# Altium schematic sheet 02 - Breakout.SchDoc("02 - Breakout")
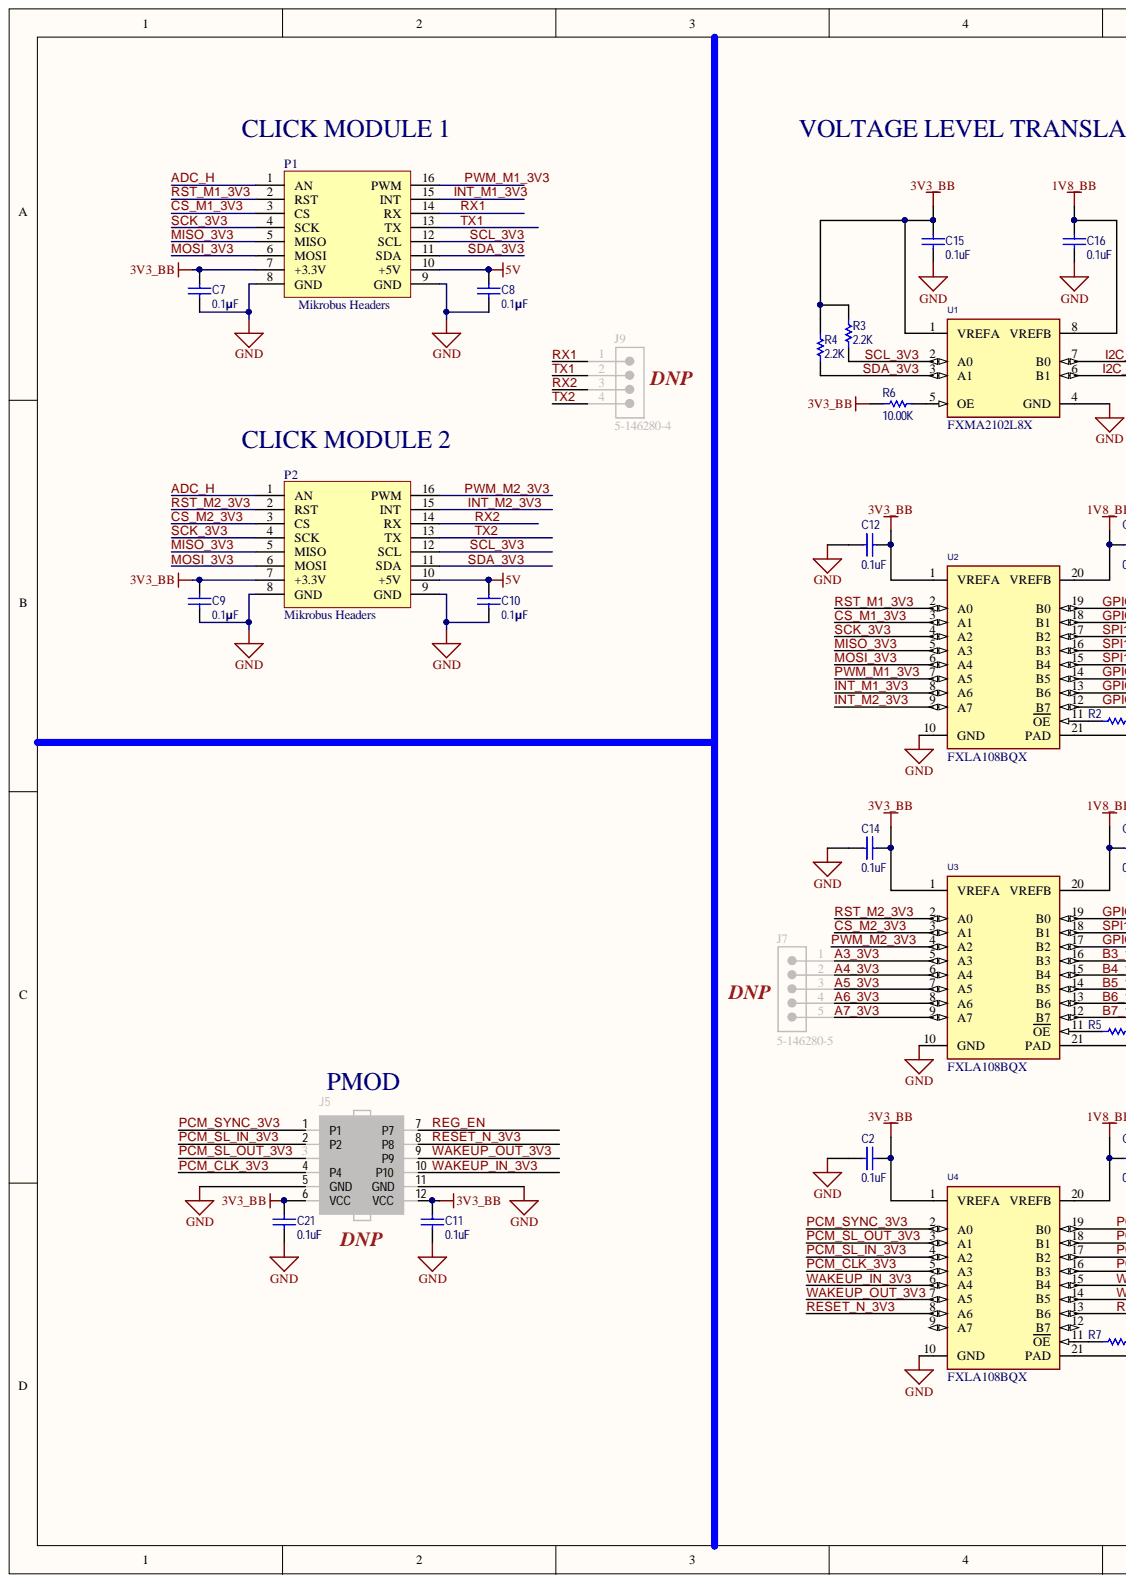
[diagram p0: 02 - Breakout.SchDoc, left]
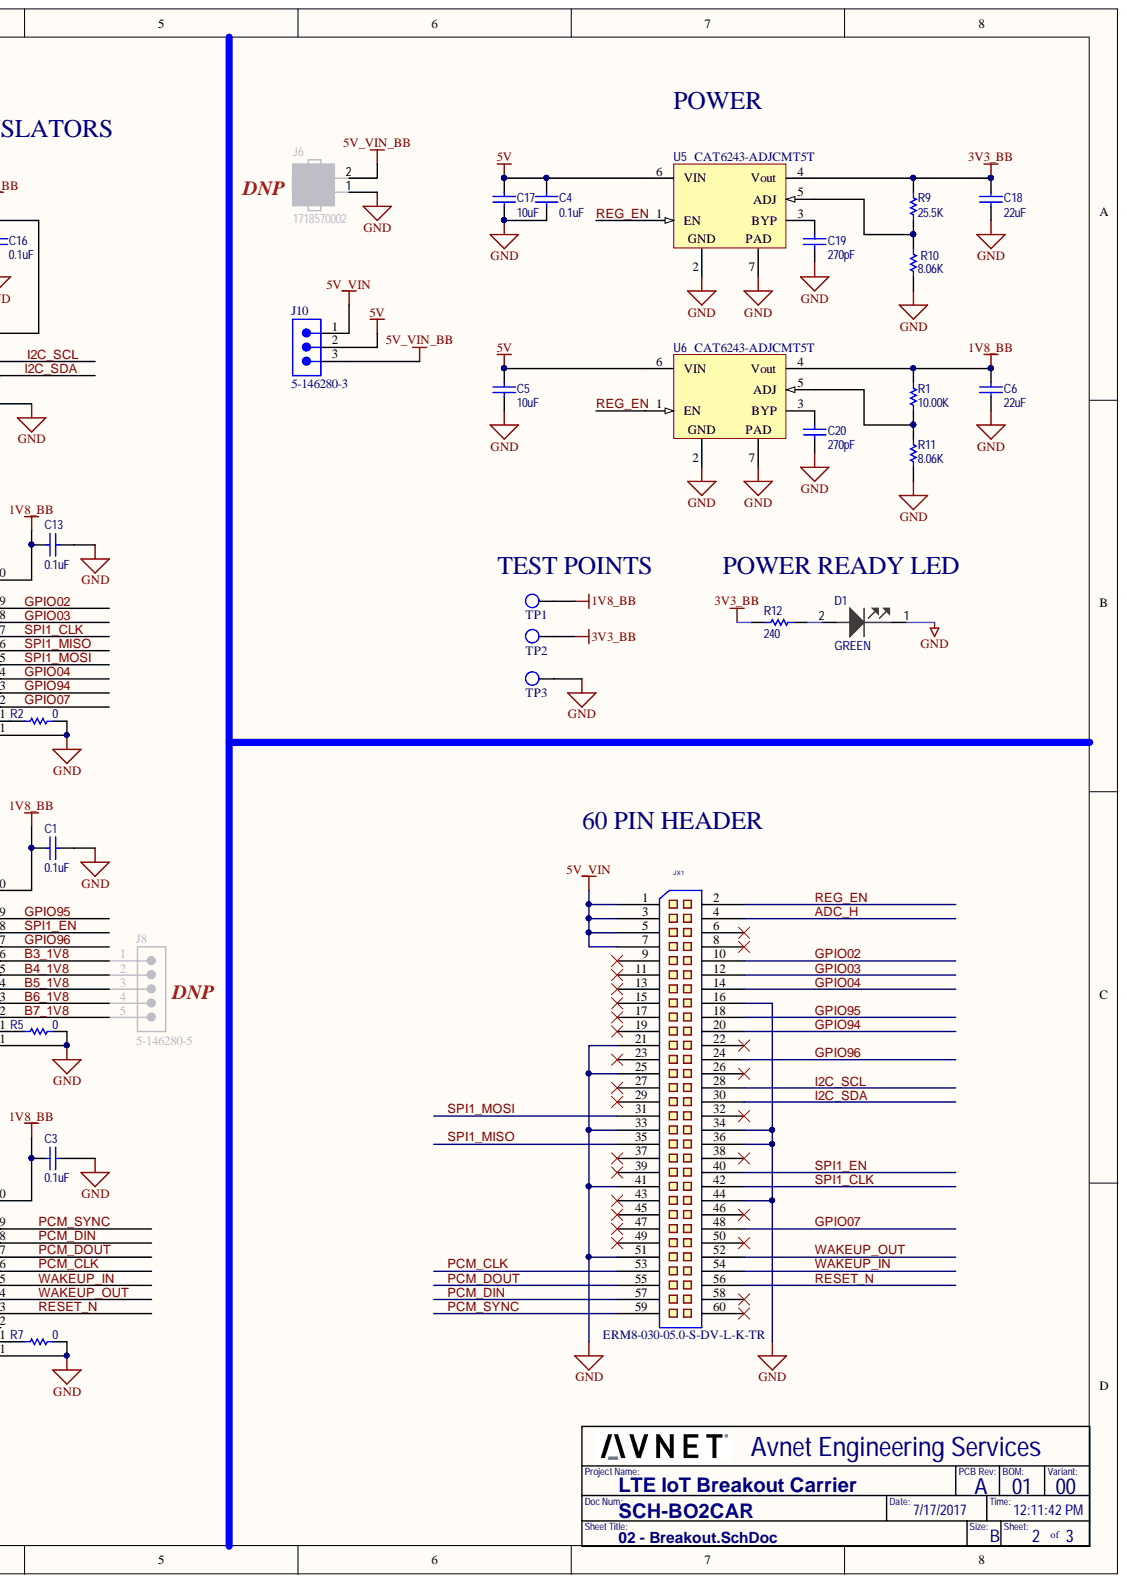
[diagram p1: 02 - Breakout.SchDoc, right]

## Parts
C1  0.1uF  [CAPC0402(1005)70_N]
C2  0.1uF  [CAPC0402(1005)70_N]
C3  0.1uF  [CAPC0402(1005)70_N]
C4  0.1uF  [CAPC0603(1608)100_N]
C5  10uF  [CAPC0402(1005)70_N]
C6  22uF  [CAPC0805(2012)155_N]
C7  Capacitance: 0.1µF  [CAPC0402(1005)70_N]  (hidden comment: 0.1uF)
C8  Capacitance: 0.1µF  [CAPC0402(1005)70_N]  (hidden comment: 0.1uF)
C9  Capacitance: 0.1µF  [CAPC0402(1005)70_N]  (hidden comment: 0.1uF)
C10  Capacitance: 0.1µF  [CAPC0402(1005)70_N]  (hidden comment: 0.1uF)
C11  0.1uF  [CAPC0402(1005)70_N]
C12  0.1uF  [CAPC0402(1005)70_N]
C13  0.1uF  [CAPC0402(1005)70_N]
C14  0.1uF  [CAPC0402(1005)70_N]
C15  0.1uF  [CAPC0402(1005)70_N]
C16  0.1uF  [CAPC0402(1005)70_N]
C17  10uF  [CAPC0402(1005)70_N]
C18  22uF  [CAPC0805(2012)155_N]
C19  270pF  [CAPC0402(1005)70_N]
C20  270pF  [CAPC0402(1005)70_N]
C21  0.1uF  [CAPC0402(1005)70_N]
D1  Color: GREEN  Component Type: LED  [D0603 (1608 Metric), GREEN]  (hidden comment: LED SMARTLED 570NM GREEN 0603)
J5  INDUSTRIAL  [REC-PTH-VERT-12D-0.100 (2.54mm)]  (value not drawn)
J6  1718570002  [1718570002]
J7  5-146280-5  [HDR-U-TH-VERT-5S-0.100 (2.54mm)]
J8  5-146280-5  [HDR-U-TH-VERT-5S-0.100 (2.54mm)]
J9  5-146280-4  [HDR-U-TH-VERT-4S-0.100 (2.54mm)]
J10  5-146280-3  [HDR-U-TH-VERT-3S-0.100 (2.54mm)]
JX1  ERM8-030-05.0-S-DV-L-K-TR  [ERM8-030-XX.X-X-DV]
P1  Mikrobus Headers  Material: Mikrobus  [MIKROBUS HOST CONN.]  (no BOM)
P2  Mikrobus Headers  Material: Mikrobus  [MIKROBUS HOST CONN.]  (no BOM)
R1  10.00K  [RESC0402(1005)_N]
R2  0  [RESC0402(1005)_N]
R3  2.2K  [RESC0402(1005)_N]
R4  2.2K  [RESC0402(1005)_N]
R5  0  [RESC0402(1005)_N]
R6  10.00K  [RESC0402(1005)_N]
R7  0  [RESC0402(1005)_N]
R9  25.5K  [RESC0402(1005)_N]
R10  8.06K  [RESC0402(1005)_N]
R11  8.06K  [RESC0402(1005)_N]
R12  240  [RESC0402(1005)_N]
TP1  Test Point-0.045"  [TP1]  (value not drawn)  (no BOM)
TP2  Test Point-0.045"  [TP1]  (value not drawn)  (no BOM)
TP3  Test Point-0.045"  [TP1]  (value not drawn)  (no BOM)
U1  FXMA2102L8X  [8-UFQFN_FXMA2102]
U2  FXLA108BQX  [20-WFQFN_EP_FXLA108]
U3  FXLA108BQX  [20-WFQFN_EP_FXLA108]
U4  FXLA108BQX  [20-WFQFN_EP_FXLA108]
U5  CAT6243-ADJCMT5T  [6-WDFN Exposed Pad]
U6  CAT6243-ADJCMT5T  [6-WDFN Exposed Pad]

## Nets
1V8_BB: C1.1 C3.1 C6.2 C13.1 C16.2 R1.2 TP1.1 U1.8(VREFB) U2.20(VREFB) U3.20(VREFB) U4.20(VREFB) U6.4(Vout)
3V3_BB: C2.2 C7.2 C9.2 C11.2 C12.1 C14.2 C15.2 C18.2 C21.2 J5.6(VCC) J5.12(VCC) P1.7(+3.3V) P2.7(+3.3V) R3.2 R4.2 R6.1 R9.2 R12.1 TP2.1 U1.1(VREFA) U2.1(VREFA) U3.1(VREFA) U4.1(VREFA) U5.4(Vout)
5V: C4.1 C5.2 C8.2 C10.2 C17.2 J10.2 P1.10(+5V) P2.10(+5V) U5.6(VIN) U6.6(VIN)
5V_VIN: J10.1 JX1.1 JX1.3 JX1.5 JX1.7
5V_VIN_BB: J6.2 J10.3
GND: C1.2 C2.1 C3.2 C4.2 C5.1 C6.1 C7.1 C8.1 C9.1 C10.1 C11.1 C12.2 C13.2 C14.1 C15.1 C16.1 C17.1 C18.1 C19.1 C20.1 C21.1 D1.1 J5.5(GND) J5.11(GND) J6.1 JX1.16 JX1.21 JX1.25 JX1.33 JX1.34 JX1.36 JX1.41 JX1.44 JX1.51 P1.8(GND) P1.9(GND) P2.8(GND) P2.9(GND) R2.2 R5.2 R7.2 R10.1 R11.1 TP3.1 U1.4(GND) U2.10(GND) U2.21(PAD) U3.10(GND) U3.21(PAD) U4.10(GND) U4.21(PAD) U5.2 U5.7 U6.2 U6.7
A3_3V3: J7.1 U3.5(A3)
A4_3V3: J7.2 U3.6(A4)
A5_3V3: J7.3 U3.7(A5)
A6_3V3: J7.4 U3.8(A6)
A7_3V3: J7.5 U3.9(A7)
ADC_H: JX1.4 P1.1(AN) P2.1(AN)
B3_1V8: J8.1 U3.16(B3)
B4_1V8: J8.2 U3.15(B4)
B5_1V8: J8.3 U3.14(B5)
B6_1V8: J8.4 U3.13(B6)
B7_1V8: J8.5 U3.12(B7)
CS_M1_3V3: P1.3(CS) U2.3(A1)
CS_M2_3V3: P2.3(CS) U3.3(A1)
GPIO02: JX1.10 U2.19(B0)
GPIO03: JX1.12 U2.18(B1)
GPIO04: JX1.14 U2.14(B5)
GPIO07: JX1.48 U2.12(B7)
GPIO94: JX1.20 U2.13(B6)
GPIO95: JX1.18 U3.19(B0)
GPIO96: JX1.24 U3.17(B2)
I2C_SCL: JX1.28 U1.7(B0)
I2C_SDA: JX1.30 U1.6(B1)
INT_M1_3V3: P1.15(INT) U2.8(A6)
INT_M2_3V3: P2.15(INT) U2.9(A7)
MISO_3V3: P1.5(MISO) P2.5(MISO) U2.5(A3)
MOSI_3V3: P1.6(MOSI) P2.6(MOSI) U2.6(A4)
PCM_CLK: JX1.53 U4.16(B3)
PCM_CLK_3V3: J5.4(P4) U4.5(A3)
PCM_DIN: JX1.57 U4.18(B1)
PCM_DOUT: JX1.55 U4.17(B2)
PCM_SL_IN_3V3: J5.2(P2) U4.4(A2)
PCM_SL_OUT_3V3: J5.3(P3) U4.3(A1)
PCM_SYNC: JX1.59 U4.19(B0)
PCM_SYNC_3V3: J5.1(P1) U4.2(A0)
PWM_M1_3V3: P1.16(PWM) U2.7(A5)
PWM_M2_3V3: P2.16(PWM) U3.4(A2)
REG_EN: J5.7(P7) JX1.2 U5.1(EN) U6.1(EN)
RESET_N: JX1.56 U4.13(B6)
RESET_N_3V3: J5.8(P8) U4.8(A6)
RST_M1_3V3: P1.2(RST) U2.2(A0)
RST_M2_3V3: P2.2(RST) U3.2(A0)
RX1: J9.1 P1.14(RX)
RX2: J9.3 P2.14(RX)
SCK_3V3: P1.4(SCK) P2.4(SCK) U2.4(A2)
SCL_3V3: P1.12(SCL) P2.12(SCL) R3.1 U1.2(A0)
SDA_3V3: P1.11(SDA) P2.11(SDA) R4.1 U1.3(A1)
SPI1_CLK: JX1.42 U2.17(B2)
SPI1_EN: JX1.40 U3.18(B1)
SPI1_MISO: JX1.35 U2.16(B3)
SPI1_MOSI: JX1.31 U2.15(B4)
TX1: J9.2 P1.13(TX)
TX2: J9.4 P2.13(TX)
WAKEUP_IN: JX1.54 U4.15(B4)
WAKEUP_IN_3V3: J5.10(P10) U4.6(A4)
WAKEUP_OUT: JX1.52 U4.14(B5)
WAKEUP_OUT_3V3: J5.9(P9) U4.7(A5)
NetC19_2: C19.2 U5.3(BYP)
NetC20_2: C20.2 U6.3(BYP)
NetD1_2: D1.2 R12.2
NetR1_1: R1.1 R11.2 U6.5(ADJ)
NetR2_1: R2.1 U2.11(OE)
NetR5_1: R5.1 U3.11(OE)
NetR6_2: R6.2 U1.5(OE)
NetR7_1: R7.1 U4.11(OE)
NetR9_1: R9.1 R10.2 U5.5(ADJ)

Not connected: JX1 6 8 9 11 13 15 17 19 22 23 26 27 29 32 37 38 39 43 45 46 47 49 50 58 60; U4 9(A7) 12(B7)

## Library data of the parts
C1-C3 C11-C16 C21 = AVPC-0000222,A-2: CAP CER 0.1UF 16V 10% X5R 0402; Material: CERAMIC; MFG 1: AVX; MFGPN 1: 0402YD104KAT2A; Mount Type (SM/TH): SM; Numeric Value (R=Ω, C=uF, L=uH, FB=Ω ): 0.1; Polarized (Y/N): N; Preferrence Code: A; Temp-Range: -55 to 85C; AES PN: AVPC-0000222; Component Kind: Standard; Component Type: CAP; Dielectric Type: X5R; MFG 1 Datasheet link: http://datasheets.avx.com/cx5r.pdf; Package: 0402 (1005 Metric); Rated Voltage: 16V; RoHS: Y; Tolerance: 10%; Value: 0.1uF; Library Name: AES Altium Vault
C4 = AVPC-0000061,A-1: CAP CER 0.1UF 50V 10% X7R 0603; Material: CERAMIC; MFG 1: AVX; MFGPN 1: 06035C104KAT2A; Mount Type (SM/TH): SM; Numeric Value (R=Ω, C=uF, L=uH, FB=Ω ): 0.1; Polarized (Y/N): N; Preferrence Code: A; Temp-Range: -55 to 125C; AES PN: AVPC-0000061; Component Kind: Standard; Component Type: CAP; Dielectric Type: X7R; MFG 1 Datasheet link: http://www.avx.com/docs/Catalogs/cx7r.pdf; Package: 0603 (1608 Metric); Rated Voltage: 50V; RoHS: Y; Tolerance: 10%; Value: 0.1uF; Library Name: AES Altium Vault
C5 C17 = AVPC-0000035,A-1: CAP CER 10UF 10V 20% X5R 0402; Material: CERAMIC; MFG 1: SAMSUNG; MFGPN 1: CL05A106MP5NUNC; Mount Type (SM/TH): SM; Numeric Value (R=Ω, C=uF, L=uH, FB=Ω ): 10; Polarized (Y/N): N; Preferrence Code: A; Temp-Range: -55 to 85C; AES PN: AVPC-0000035; Component Kind: Standard; Component Type: CAP; Dielectric Type: X5R; MFG 1 Datasheet link: http://www.samsungsem.com/global/support/library/product-catalog/__icsFiles/afieldfile/2014/11/13/MLCC.pdf; Package: 0402 (1005 Metric); Rated Voltage: 10V; RoHS: Y; Tolerance: 20%; Value: 10uF; Library Name: AES Altium Vault
C6 C18 = AVPC-0000307,A-1: CAP CER 22UF 10V 20% X5R 0805; Material: CERAMIC; MFG 1: AVX; MFGPN 1: 0805ZD226MAT2A; Mount Type (SM/TH): SM; Numeric Value (R=Ω, C=uF, L=uH, FB=Ω ): 22; Polarized (Y/N): N; Preferrence Code: A; Temp-Range: -55 to 85C; AES PN: AVPC-0000307; Component Kind: Standard; Component Type: CAP; Dielectric Type: X5R; MFG 1 Datasheet link: http://datasheets.avx.com/cx5r.pdf; Package: 0805 (2012 Metric); Rated Voltage: 10V; RoHS: Y; Tolerance: 20%; Value: 22uF; Library Name: AES Altium Vault
C7-C10 = AVPC-0000034,A-1: CAP CER 0.1UF 25V 10% X7R 0402; Manufacturer: AVX Corporation; Manufacturer Part Number: 06035C104KAT2A; Material: CERAMIC; MFG 1: AVX; MFGPN 1: 04023C104KAT2A; Mount Type (SM/TH): SM; Numeric Value (R=Ω, C=uF, L=uH, FB=Ω ): 0.1; Polarized (Y/N): N; Preferrence Code: A; Temp-Range: -55 to 125C; Voltage - Rated: 50V; AES PN: AVPC-0000034; Applications: General Purpose; Category: Capacitors; Component Kind: Standard; ComponentLink1Description: http://www.avx.com/docs/Catalogs/cx7r.pdf; ComponentLink1URL: http://www.avx.com/docs/Catalogs/cx7r.pdf; Component Type: CAP; Dielectric Type: X7R; Features: -; Height - Seated (Max): -; Lead Spacing: -; Lead Style: -; MFG 1 Datasheet link: http://www.avx.com/docs/Catalogs/cx7r.pdf; Mounting Type: Surface Mount, MLCC; Operating Temperature: -55°C ~ 125°C; Package: 0402 (1005 Metric); Package / Case: 0603 (1608 Metric); Packaging: Cut Tape (CT); Rated Voltage: 25V; Ratings: -; RoHS: Y; Size / Dimension: 0.063" L x 0.032" W (1.60mm x 0.81mm); Temperature Coefficient: X7R; Thickness (Max): 0.035" (0.90mm); Tolerance: 10%; Value: 0.1uF; Library Name: AES Altium Vault
C19 C20 = AVPC-0000194,A-0: CAP CER 270PF 50V 10% X7R 0402; Material: CERAMIC; MFG 1: AVX; MFGPN 1: 04025C271KAT2A; Mount Type (SM/TH): SM; Numeric Value (R=Ω, C=uF, L=uH, FB=Ω ): 0.00027; Polarized (Y/N): N; Preferrence Code: A; Temp-Range: -55 to 125C; AES PN: AVPC-0000194; Component Kind: Standard; Component Type: CAP; Dielectric Type: X7R; MFG 1 Datasheet link: http://datasheets.avx.com/X7RDielectric.pdf; Package: 0402 (1005 Metric); Rated Voltage: 50V; RoHS: Y; Tolerance: 10%; Value: 270pF; Library Name: AES Altium Vault
D1 = AVDL-0000010,A-0: Forward Voltage: 1.7V; Lense Type: Diffused; MFG 1: OSRAM; MFGPN 1: LG L29K-F2J1-24-Z; Millicandela Rating: 3.5mcd; Mount Type (SM/TH): SM; Preferrence Code: A; Temp-Range: -40 to 85C; Wave-PK: 572nm; AES PN: AVDL-0000010; Component Kind: Standard; Description: LED SMARTLED 570NM GREEN 0603; MFG 1 Datasheet link: http://www.osram-os.com/Graphics/XPic2/00077107_0.pdf; Package: D0603 (1608 Metric); RoHS: Y; Wavelength: 570nm; Library Name: AES Altium Vault
J5 = AVEC-0000004,A-0: CONN RCPT 12POS .100" DUAL VERT; Header (M/F): F; MFG 1: SAMTEC; MFGPN 1: BCS-106-L-D-TE; Mount Type (SM/TH): TH; Pin Pitch: 0.100" (2.54mm); Preferrence Code: A; Shrouded (Y/N): N; Temp-Range: -55 to 125C; AES PN: AVEC-0000004; Color: Black; Component Kind: Standard; Component Type: CONNECTOR; Contact Mating Length: -; Contact Type: Gold, 10µin (0.25µm); Fastening Type: -; Features: -; Material: RECEPTACLE; MFG 1 Datasheet link: http://www.samtec.com/documents/webfiles/cpdf/BCS-1XX-XXX-X-XX-XXX-MKT.pdf; Number of Pins: 12; Number of Positions: -; Number of Rows: 2; Orientation: Vertical; Package: REC-PTH-VERT-12D-0.100 (2.54mm); Rated Current: -; Rated Voltage: -; RoHS: Y; Row Pitch: 0.100" (2.54mm); Library Name: AES Altium Vault
J6 = AVEC-0000143,A-0: KK RPC 100 HDR FRLK RTAN 02 CKT; Header (M/F): M; MFG 1: MOLEX; Mount Type (SM/TH): TH; Number of Positions: 2; Pin Pitch: 0.100(2.54mm); Preferrence Code: A; Rated Current: 4.0A (Per Pin); Rated Voltage: DWV=750V; Shrouded (Y/N): N; Temp-Range: -40 to 85C; AES PN: AVEC-0000143; Color: BLACK; Component Kind: Standard; Component Type: CONNECTOR; Contact Mating Length: 0.265" (6.73mm); Contact Type: 60µin (1.52µm); Material: HEADER; MFG 1 Datasheet link: http://www.molex.com/pdm_docs/sd/1718570002_sd.pdf; MFGPN 1: 1718570002; Number of Pins: 2; Number of Rows: 1; Orientation: RIGHT ANGLE; Package: 1718570002; RoHS: Y; Row Pitch: 0.100(2.54mm); Library Name: AES Altium Vault
J7 J8 = AVEC-0000016,A-2: CONN HEADR BRKWAY .100 5POS STR, SINGLE ROW; Header (M/F): M; MFG 1: TE; Mount Type (SM/TH): TH; Number of Positions: 5; Pin Pitch: 0.100(2.54mm); Preferrence Code: A; Rated Current: 3.0A (Per Pin); Rated Voltage: DWV=750V; Schematic Symbol: 5-Pin, Male, Single Row; Shrouded (Y/N): N; Temp-Range: -65 to 105C; AES PN: AVEC-0000016; Color: BLACK; Component Kind: Standard; Component Type: CONNECTOR; Contact Mating Length: 0.230" (5.84mm); Contact Type: Gold, 15µin (0.38µm); Fastening Type: -; Features: -; Material: HEADER; MFG 1 Datasheet link: http://www.te.com/commerce/DocumentDelivery/DDEController?Action=srchrtrv&DocNm=146280&DocType=Customer+Drawing&DocLang=English; MFGPN 1: 5-146280-5; Number of Pins: 5; Number of Rows: 1; Orientation: Vertical; Package: HDR-U-TH-VERT-5S-0.100 (2.54mm); RoHS: Y; Row Pitch: -; Library Name: AES Altium Vault
J9 = AVEC-0000015,A-2: CONN HEADR BRKWAY .100 4POS STR, SINGLE ROW; Header (M/F): M; MFG 1: TE; Mount Type (SM/TH): TH; Number of Positions: 4; Pin Pitch: 0.100(2.54mm); Preferrence Code: A; Rated Current: 3.0A (Per Pin); Rated Voltage: DWV=750V; Schematic Symbol: 4-Pin, Male, Single Row; Shrouded (Y/N): N; Temp-Range: -65 to 105C; AES PN: AVEC-0000015; Color: BLACK; Component Kind: Standard; Component Type: CONNECTOR; Contact Mating Length: 0.230" (5.84mm); Contact Type: Gold, 15µin (0.38µm); Fastening Type: -; Features: -; Material: HEADER; MFG 1 Datasheet link: http://www.te.com/commerce/DocumentDelivery/DDEController?Action=srchrtrv&DocNm=146280&DocType=Customer+Drawing&DocLang=English; MFGPN 1: 5-146280-4; Number of Pins: 4; Number of Rows: 1; Orientation: Vertical; Package: HDR-U-TH-VERT-4S-0.100 (2.54mm); RoHS: Y; Row Pitch: -; Library Name: AES Altium Vault
J10 = AVEC-0000014,A-2: CONN HEADR BRKWAY .100 3POS STR, SINGLE ROW; Header (M/F): M; MFG 1: TE; Mount Type (SM/TH): TH; Number of Positions: 3; Pin Pitch: 0.100(2.54mm); Preferrence Code: A; Rated Current: 3.0A (Per Pin); Rated Voltage: DWV=750V; Schematic Symbol: 3-Pin, Male, Single Row; Shrouded (Y/N): N; Temp-Range: -65 to 105C; AES PN: AVEC-0000014; Color: BLACK; Component Kind: Standard; Component Type: CONNECTOR; Contact Mating Length: 0.230" (5.84mm); Contact Type: Gold, 15µin (0.38µm); Fastening Type: -; Features: -; Material: HEADER; MFG 1 Datasheet link: http://www.te.com/commerce/DocumentDelivery/DDEController?Action=srchrtrv&DocNm=146280&DocType=Customer+Drawing&DocLang=English; MFGPN 1: 5-146280-3; Number of Pins: 3; Number of Rows: 1; Orientation: Vertical; Package: HDR-U-TH-VERT-3S-0.100 (2.54mm); RoHS: Y; Row Pitch: -; Library Name: AES Altium Vault
JX1 = AVEC-0000140,A-0: .8MM EDGE RATE TERMINAL ASSEMBLY; Header (M/F): M; MFG 1: SAMTEC; Mount Type (SM/TH): SM; Number of Positions: 30; Pin Pitch: 0.030" (0.762mm); Preferrence Code: A; Row Pitch: 0.031" (0.80mm); Shrouded (Y/N): Y; Temp-Range: -55 to 125C; AES PN: AVEC-0000140; Color: Black; Component Kind: Standard; Component Type: CONNECTOR; Contact Mating Length: 0.309" (7.84mm); Contact Type: Gold, 50uin (1.27um); Features: Board Guide; Material: HEADER; MFG 1 Datasheet link: http://suddendocs.samtec.com/catalog_english/erm5.pdf; MFGPN 1: ERM8-030-05.0-S-DV-L-K-TR; Number of Pins: 60; Number of Rows: 2; Orientation: Vertical; Package: ERM8-030-05.0-S-DV-L-K-TR; RoHS: Y; Library Name: AES Altium Vault
P1 P2 = AVMM-0000001,A-3: AES PN: AVMM-0000001; Component Type: Header Footprint; Description: Mikrobus Headers; MFG 1: Mikrobus; Library Name: AES Altium Vault
R1 R6 = AVPR-0000977,A-2: RES 10.0K OHM 0402 1% 1/16W; MFG 1: TBD; Mount Type (SM/TH): SM; Numeric Value (R=Ω, C=uF, L=uH, FB=Ω ): 10000; Preferrence Code: A; Rated Power: 0.063W; Temp-Range: -55 to 125C; AES PN: AVPR-0000977; Component Kind: Standard; Component Type: RESISTOR; Material: Thick Film; MFG 2: TBD; MFG 3: TBD; MFGPN 1: TBD; MFGPN 2: TBD; MFGPN 3: TBD; Package: 0402 (1005 Metric); RoHS: Y; Tolerance: 1%; Value: 10.00K; Library Name: AES Altium Vault
R2 R5 R7 = AVPR-0000585,A-2: RES 0.0 OHM JUMP 0402 1/16W; MFG 1: TBD; Mount Type (SM/TH): SM; Preferrence Code: A; Rated Power: 0.063W; Temp-Range: -55 to 125C; Tolerance: 1%; AES PN: AVPR-0000585; Component Kind: Standard; Component Type: RESISTOR; Material: Thick Film; MFG 2: TBD; MFG 3: TBD; MFGPN 1: TBD; MFGPN 2: TBD; MFGPN 3: TBD; Numeric Value (R=Ω, C=uF, L=uH, FB=Ω ): 0; Package: 0402 (1005 Metric); RoHS: Y; Value: 0; Library Name: AES Altium Vault
R3 R4 = AVPR-0005367,A-0: RES 2.2K OHM 0402 1% 1/16W; MFG 1: TBD; Mount Type (SM/TH): SM; Numeric Value (R=Ω, C=uF, L=uH, FB=Ω ): 2200; Preferrence Code: A; Rated Power: 0.063W; Temp-Range: -55 to 125C; AES PN: AVPR-0005367; Component Kind: Standard; Component Type: RESISTOR; Material: Thick Film; MFG 2: TBD; MFG 3: TBD; MFGPN 1: TBD; MFGPN 2: TBD; MFGPN 3: TBD; Package: 0402 (1005 Metric); RoHS: Y; Tolerance: 1%; Value: 2.2K; Library Name: AES Altium Vault
R9 = AVPR-0001017,A-2: RES 25.5K OHM 0402 1% 1/16W; MFG 1: TBD; Mount Type (SM/TH): SM; Numeric Value (R=Ω, C=uF, L=uH, FB=Ω ): 25500; Preferrence Code: A; Rated Power: 0.063W; Temp-Range: -55 to 125C; AES PN: AVPR-0001017; Component Kind: Standard; Component Type: RESISTOR; Material: Thick Film; MFG 2: TBD; MFG 3: TBD; MFGPN 1: TBD; MFGPN 2: TBD; MFGPN 3: TBD; Package: 0402 (1005 Metric); RoHS: Y; Tolerance: 1%; Value: 25.5K; Library Name: AES Altium Vault
R10 R11 = AVPR-0000968,A-2: RES 8.06K OHM 0402 1% 1/16W; MFG 1: TBD; Mount Type (SM/TH): SM; Numeric Value (R=Ω, C=uF, L=uH, FB=Ω ): 8060; Preferrence Code: A; Rated Power: 0.063W; Temp-Range: -55 to 125C; AES PN: AVPR-0000968; Component Kind: Standard; Component Type: RESISTOR; Material: Thick Film; MFG 2: TBD; MFG 3: TBD; MFGPN 1: TBD; MFGPN 2: TBD; MFGPN 3: TBD; Package: 0402 (1005 Metric); RoHS: Y; Tolerance: 1%; Value: 8.06K; Library Name: AES Altium Vault
R12 = AVPR-0000820,A-2: RES 240 OHM 0402 1% 1/16W; MFG 1: TBD; Mount Type (SM/TH): SM; Preferrence Code: A; Rated Power: 0.063W; Temp-Range: -55 to 125C; AES PN: AVPR-0000820; Component Kind: Standard; Component Type: RESISTOR; Material: Thick Film; MFG 2: TBD; MFG 3: TBD; MFGPN 1: TBD; MFGPN 2: TBD; MFGPN 3: TBD; Numeric Value (R=Ω, C=uF, L=uH, FB=Ω ): 240; Package: 0402 (1005 Metric); RoHS: Y; Tolerance: 1%; Value: 240; Library Name: AES Altium Vault
TP1-TP3 = AVTT-0000002,A-1: AES PN: AVTT-0000002; Component Type: Test Point; Description: Test Point-0.045"; Material: -; MFG 1: -; MFGPN 1: -; Mount Type (SM/TH): -; Package: 0.045"; Preferrence Code: -; RoHS: -; Temp-Range: -; Library Name: AES Altium Vault
U1 = AVID-0000113,A-0: TRANSLATOR/BUFF/REPEAT 8-MLP; MFG 1: On Semi; Mount Type (SM/TH): SM; Number of Channels: 2 (Per Circuit); Preferrence Code: A; Supply Voltage: 1.65 to 5.5V; Temp-Range: -40 to 85C; AES PN: AVID-0000113; Applications: Translator, Bidirectional, 3-State; Component Kind: Standard; Component Type: IC; Material: DQFN; MFG 1 Datasheet link: https://www.fairchildsemi.com/datasheets/FX/FXMA2102.pdf; MFGPN 1: FXMA2102L8X; Package: 8-UFQFN; RoHS: Y; Library Name: AES Altium Vault
U2-U4 = AVID-0000114,A-0: TRANSLATOR 8BIT DUAL LV 20DQFN; MFG 1: On Semi; Mount Type (SM/TH): SM; Number of Channels: 8 (Per Circuit); Preferrence Code: A; Supply Voltage: 1.1 to 3.6V; Temp-Range: -40 to 85C; AES PN: AVID-0000114; Applications: Translator, Bidirectional, 3-State; Component Kind: Standard; Component Type: IC; Material: DQFN; MFG 1 Datasheet link: https://www.fairchildsemi.com/datasheets/FX/FXLA108.pdf; MFGPN 1: FXLA108BQX; Package: 20-WFQFN Exposed Pad; RoHS: Y; Library Name: AES Altium Vault
U5 U6 = AVIA-0000053,A-0: IC REG LDO ADJ 1A 6WDFN; Input Voltage: 0.8  to 5V; MFG 1: ON SEMI; Mount Type (SM/TH): SM; Number of Outputs: 1; Output Type: Positive Adjustable; Output Voltage: 0.8 to 5V; Preferrence Code: A; Temp-Range: -40 to 85C; AES PN: AVIA-0000053; Applications: LDO; Component Kind: Standard; Component Type: IC; Material: DFN; MFG 1 Datasheet link: http://www.onsemi.com/pub/Collateral/CAT6243-D.PDF; MFGPN 1: CAT6243-ADJCMT5T; Output Current: 1A; Package: 6-WDFN Exposed Pad; RoHS: Y; Library Name: AES Altium Vault
